# Altium schematic sheet top.SchDoc
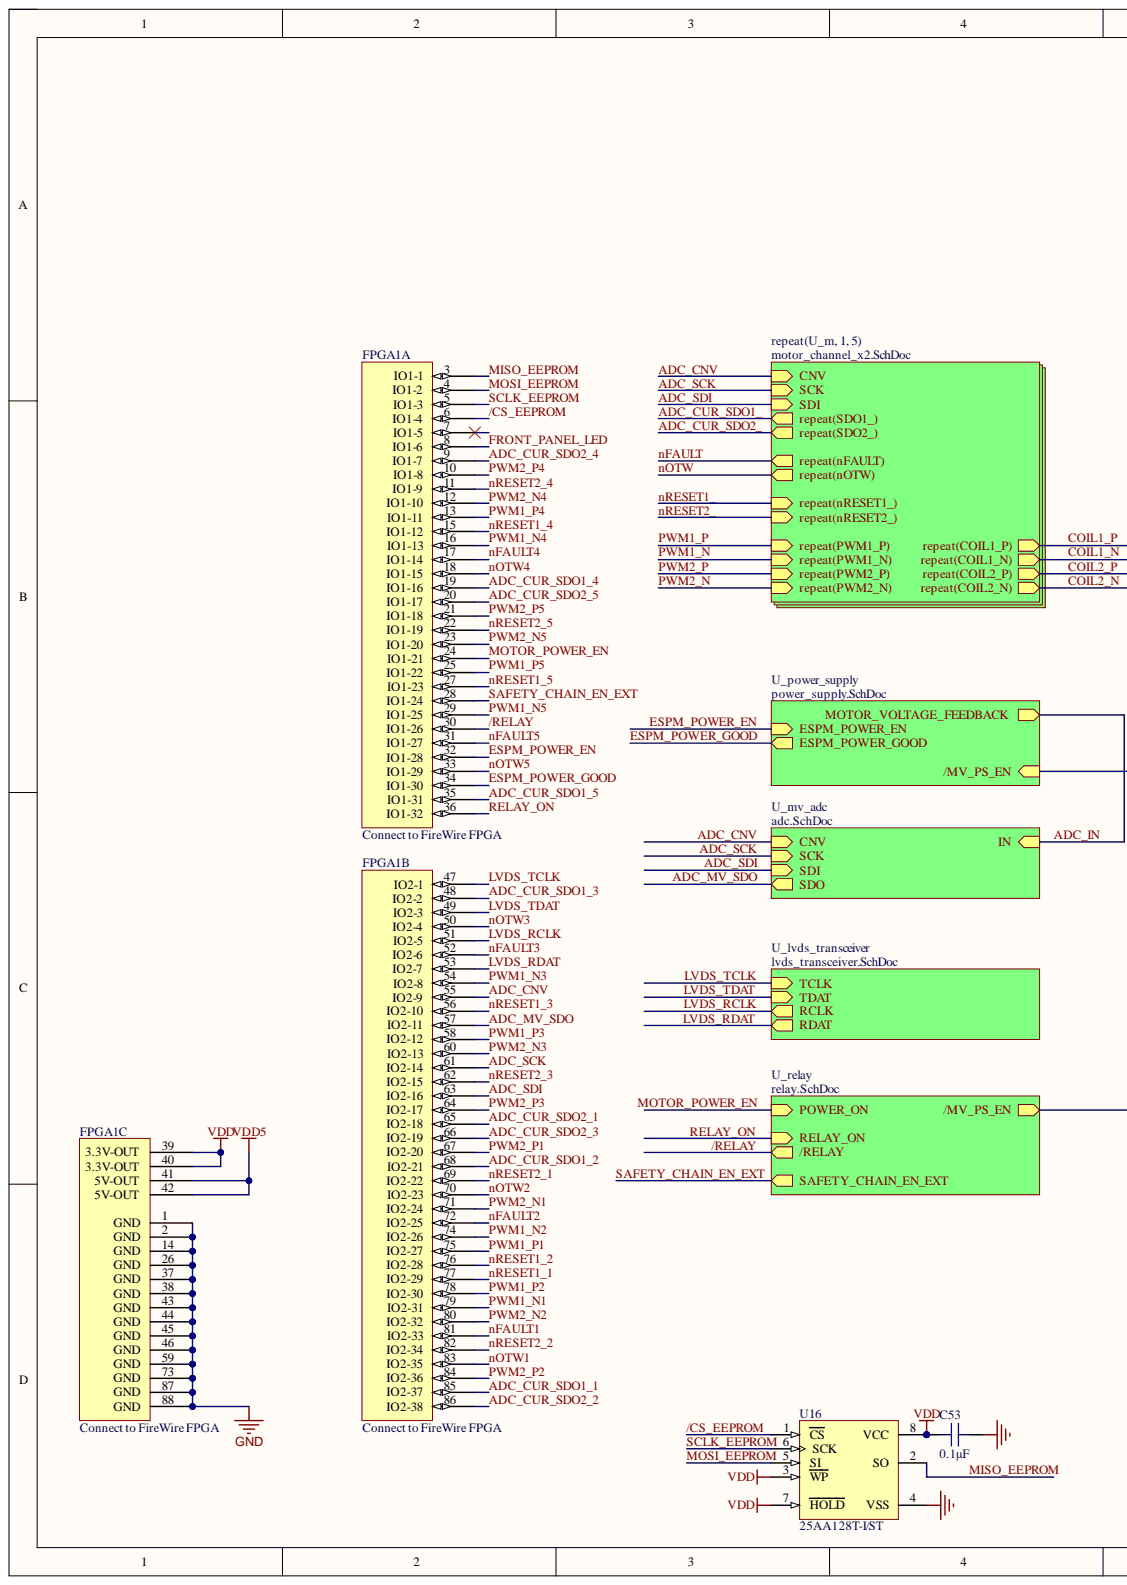
[diagram p0: top.SchDoc, left]
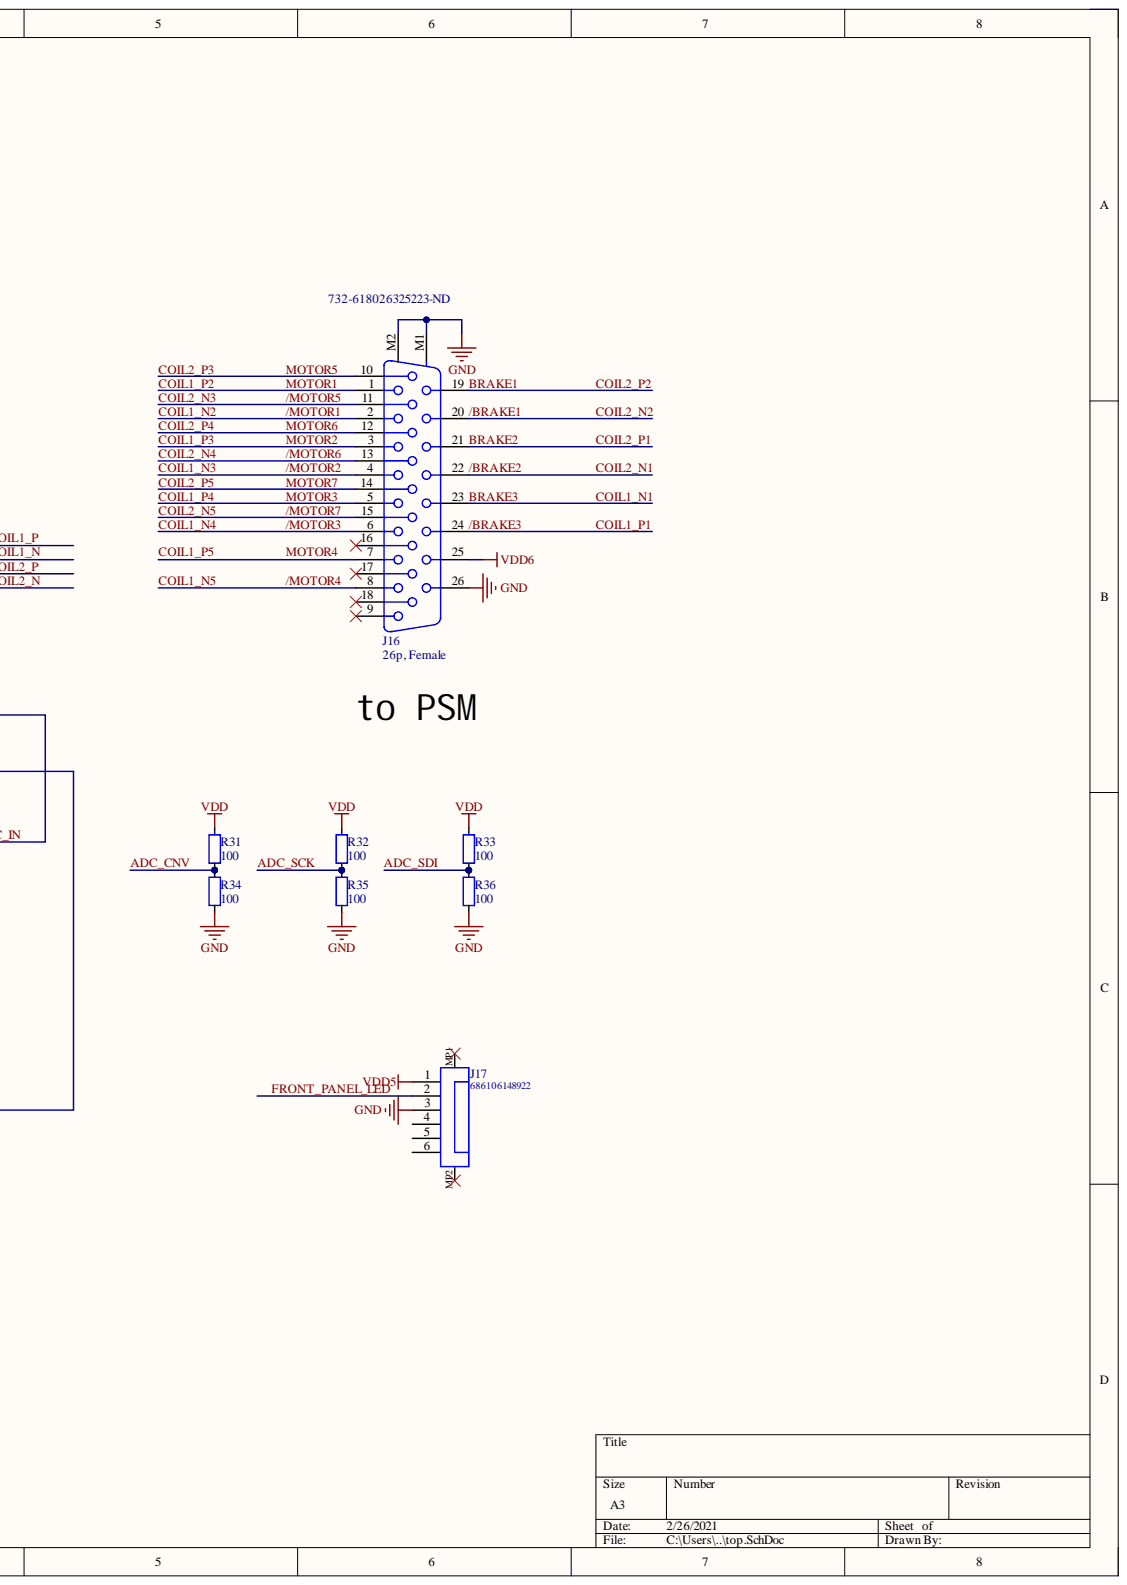
[diagram p1: top.SchDoc, right]

## Parts
C53  0.1µF  [FP-GRM155-0_05-IPC_B]
FPGA1  Connect to FireWire FPGA  [FPGA-dummy]  (parts A,B,C)
J16  26p, Female  Supplier Part Number 1: 732-618026325223-ND  [618026325223]
J17  686106148922  [686106148922]
R31  value 100  [FP-CRCW0805-HPe3-IPC_B]  (hidden comment: Res_0805)
R32  value 100  [FP-CRCW0805-HPe3-IPC_B]  (hidden comment: Res_0805)
R33  value 100  [FP-CRCW0805-HPe3-IPC_B]  (hidden comment: Res_0805)
R34  value 100  [FP-CRCW0805-HPe3-IPC_B]  (hidden comment: Res_0805)
R35  value 100  [FP-CRCW0805-HPe3-IPC_B]  (hidden comment: Res_0805)
R36  value 100  [FP-CRCW0805-HPe3-IPC_B]  (hidden comment: Res_0805)
U16  25AA128T-I/ST  [TSSOP-ST8_N]

## Nets
GND: C53.2 FPGA1.1(GND) FPGA1.2(GND) FPGA1.14(GND) FPGA1.26(GND) FPGA1.37(GND) FPGA1.38(GND) FPGA1.43(GND) FPGA1.44(GND) FPGA1.45(GND) FPGA1.46(GND) FPGA1.59(GND) FPGA1.73(GND) FPGA1.87(GND) FPGA1.88(GND) J16.26 J16.M1 J16.M2 J17.3 R34.1 R35.1 R36.1 U16.4(VSS)
VDD: C53.1 FPGA1.39(3.3V-OUT) FPGA1.40(3.3V-OUT) R31.2 R32.2 R33.2 U16.3(WP) U16.7(HOLD) U16.8(VCC)
VDD5: FPGA1.41(5V-OUT) FPGA1.42(5V-OUT) J17.1
VDD6: J16.25
/BRAKE1: J16.20
/BRAKE2: J16.22
/BRAKE3: J16.24
/CS_EEPROM: FPGA1.6(IO1-4) U16.1(CS)
/MOTOR1: J16.2
/MOTOR2: J16.4
/MOTOR3: J16.6
/MOTOR4: J16.8
/MOTOR5: J16.11
/MOTOR6: J16.13
/MOTOR7: J16.15
/RELAY: FPGA1.30(IO1-26)
ADC_CNV: FPGA1.55(IO2-9) R31.1 R34.2
ADC_CUR_SDO1_1: FPGA1.85(IO2-37)
ADC_CUR_SDO1_2: FPGA1.68(IO2-21)
ADC_CUR_SDO1_3: FPGA1.48(IO2-2)
ADC_CUR_SDO1_4: FPGA1.19(IO1-16)
ADC_CUR_SDO1_5: FPGA1.35(IO1-31)
ADC_CUR_SDO2_1: FPGA1.65(IO2-18)
ADC_CUR_SDO2_2: FPGA1.86(IO2-38)
ADC_CUR_SDO2_3: FPGA1.66(IO2-19)
ADC_CUR_SDO2_4: FPGA1.9(IO1-7)
ADC_CUR_SDO2_5: FPGA1.20(IO1-17)
ADC_MV_SDO: FPGA1.57(IO2-11)
ADC_SCK: FPGA1.61(IO2-14) R32.1 R35.2
ADC_SDI: FPGA1.63(IO2-16) R33.1 R36.2
BRAKE1: J16.19
BRAKE2: J16.21
BRAKE3: J16.23
COIL1_P2: J16.1
COIL1_P3: J16.3
COIL1_P4: J16.5
COIL1_P5: J16.7
COIL2_P3: J16.10
COIL2_P4: J16.12
COIL2_P5: J16.14
ESPM_POWER_EN: FPGA1.32(IO1-28)
ESPM_POWER_GOOD: FPGA1.34(IO1-30)
FRONT_PANEL_LED: FPGA1.8(IO1-6) J17.2
LVDS_RCLK: FPGA1.51(IO2-5)
LVDS_RDAT: FPGA1.53(IO2-7)
LVDS_TCLK: FPGA1.47(IO2-1)
LVDS_TDAT: FPGA1.49(IO2-3)
MISO_EEPROM: FPGA1.3(IO1-1) U16.2(SO)
MOSI_EEPROM: FPGA1.4(IO1-2) U16.5(SI)
MOTOR_POWER_EN: FPGA1.24(IO1-21)
nFAULT1: FPGA1.81(IO2-33)
nFAULT2: FPGA1.72(IO2-25)
nFAULT3: FPGA1.52(IO2-6)
nFAULT4: FPGA1.17(IO1-14)
nFAULT5: FPGA1.31(IO1-27)
nOTW1: FPGA1.83(IO2-35)
nOTW2: FPGA1.70(IO2-23)
nOTW3: FPGA1.50(IO2-4)
nOTW4: FPGA1.18(IO1-15)
nOTW5: FPGA1.33(IO1-29)
nRESET1_1: FPGA1.77(IO2-29)
nRESET1_2: FPGA1.76(IO2-28)
nRESET1_3: FPGA1.56(IO2-10)
nRESET1_4: FPGA1.15(IO1-12)
nRESET1_5: FPGA1.27(IO1-23)
nRESET2_1: FPGA1.69(IO2-22)
nRESET2_2: FPGA1.82(IO2-34)
nRESET2_3: FPGA1.62(IO2-15)
nRESET2_4: FPGA1.11(IO1-9)
nRESET2_5: FPGA1.22(IO1-19)
PWM1_N1: FPGA1.79(IO2-31)
PWM1_N2: FPGA1.74(IO2-26)
PWM1_N3: FPGA1.54(IO2-8)
PWM1_N4: FPGA1.16(IO1-13)
PWM1_N5: FPGA1.29(IO1-25)
PWM1_P1: FPGA1.75(IO2-27)
PWM1_P2: FPGA1.78(IO2-30)
PWM1_P3: FPGA1.58(IO2-12)
PWM1_P4: FPGA1.13(IO1-11)
PWM1_P5: FPGA1.25(IO1-22)
PWM2_N1: FPGA1.71(IO2-24)
PWM2_N2: FPGA1.80(IO2-32)
PWM2_N3: FPGA1.60(IO2-13)
PWM2_N4: FPGA1.12(IO1-10)
PWM2_N5: FPGA1.23(IO1-20)
PWM2_P1: FPGA1.67(IO2-20)
PWM2_P2: FPGA1.84(IO2-36)
PWM2_P3: FPGA1.64(IO2-17)
PWM2_P4: FPGA1.10(IO1-8)
PWM2_P5: FPGA1.21(IO1-18)
RELAY_ON: FPGA1.36(IO1-32)
SAFETY_CHAIN_EN_EXT: FPGA1.28(IO1-24)
SCLK_EEPROM: FPGA1.5(IO1-3) U16.6(SCK)
NetFPGA1_7: FPGA1.7(IO1-5)
NetJ16_9: J16.9
NetJ16_16: J16.16
NetJ16_17: J16.17
NetJ16_18: J16.18
NetJ17_4: J17.4
NetJ17_5: J17.5
NetJ17_6: J17.6
NetJ17_MP1: J17.MP1
NetJ17_MP2: J17.MP2
/MV_PS_EN:
ADC_IN:

## Library data of the parts
C53 = 04023C104KAT2A: CAP CER 0.1UF 25V X7R 0402; Manufacturer: AVX Corporation; Database Table Name: Capacitors - Ceramic - 0402; Tolerance: ±10%; Applications: General Purpose; Capacitance: 0.1µF; Component Kind: Standard; ComponentLink1Description: Datasheet; ComponentLink1URL: http://datasheets.avx.com/X7RDielectric.pdf; ComponentLink2Description: DigiKey Link; ComponentLink2URL: http://digikey.com/product-detail/en/avx-corporation/04023C104KAT2A/478-7868-1-ND/3881491; Device Package: 0402 (1005 Metric); LastUpdated: 9/2/2020 1:02:21 AM; Library Name: Celestial Altium Library - altium_library.DbLib; Lifecycle Status: Active; Minimum Order: 1; Mounting Type: Surface Mount, MLCC; Operating Temperature: -55°C ~ 125°C; Package / Case: 0402 (1005 Metric); Packaging: CutTape; Part Number: 04023C104KAT2A; Part Status: Active; PartId: 3190; Price: 0.13; Series: -; Size / Dimension: 0.039" L x 0.020" W (1.00mm x 0.50mm); Supplier 1: DigiKey; Supplier Part Number 1: 478-7868-1-ND; Temperature Coefficient: X7R; Thickness (Max): 0.022" (0.55mm); Value: 0.1µF; Voltage - Rated: 25V
FPGA1 = fpga_dummy: ClassName: noclearancecheck; Component Kind: Standard; Library Name: dvrk-s-pwm.IntLib
J16 = 618026325223: WR-DSUB Female High Density Angled PCB Connector without Hex Screw, 26p; Manufacturer: Wurth Electronics; PinNumber: 26; Component Kind: Standard; ComponentLink1Description: Manufacturer; ComponentLink1URL: http://www.we-online.de; ComponentLink2Description: Datasheet; ComponentLink2URL: http://katalog.we-online.de/em/datasheet/6180xx325223.pdf; Manufacturer Part Number: 618026325223; PartNumber: 618026325223; Pricing 1: 1=8.10000, 10=7.69500, 50=7.15500, 100=6.75000, 250=6.21000, 500=5.40002 (USD); Published: June 2016; RoHS: Yes; RoHS Compliant: Yes; Stock 1: 325; Supplier 1: Digi-Key; system: connector; Library Name: dvrk-s-pwm.IntLib; Publisher: Wurth Elektronik
J17 = CMP-1502-01513-1: SMT ZIF Connectors Hinge Type WR-FPC, Horizontal, Bottom Contact, pitch 1 mm, 6 pins; Manufacturer: Wurth Elektronik; Contact Resistance: 50mOhm; Pin Number: 6; Pitch: 1mm; Rated Current: 1A; Withstanding Voltage: 500VAC; Working Voltage: 100VAC; Component Kind: Standard; Contact Design: BottomContact; Datasheet URL: https://katalog.we-online.de/em/datasheet/686106148922.pdf; Library Name: Altium Content Vault; Manufacturer URL: http://www.we-online.de; Mounting Technology: SMT; Operating Temperature: -40to105degC; Orientation: Horizontal; Package Reference: 686106148922; Packaging: TapeandReel; Part Number: 686106148922; Publisher: WurthElektronik
R31-R36 = Res_0805: Resistor; Component Kind: Standard; Library Name: SCH_Passive.SchLib
U16 = CMP-0165-00422-1: 128K SPI Bus Serial EEPROM, 8-Pin TSSOP, Industrial Temperature, Tape and Reel; Code_JEDEC: MO-153; Component Kind: Standard; DatasheetVersion: revD, Jun-2009; Library Name: Altium Content Vault; PackageDescription: 8-Lead Plastic Thin Shrink Small Outline (ST) - 4.4mm Body [TSSOP]; PackageReference: TSSOP-ST8; PackageVersion: revBB, Aug-2009
